# Altium schematic sheet GateDriver_LF.SchDoc(GateDriver_LF)
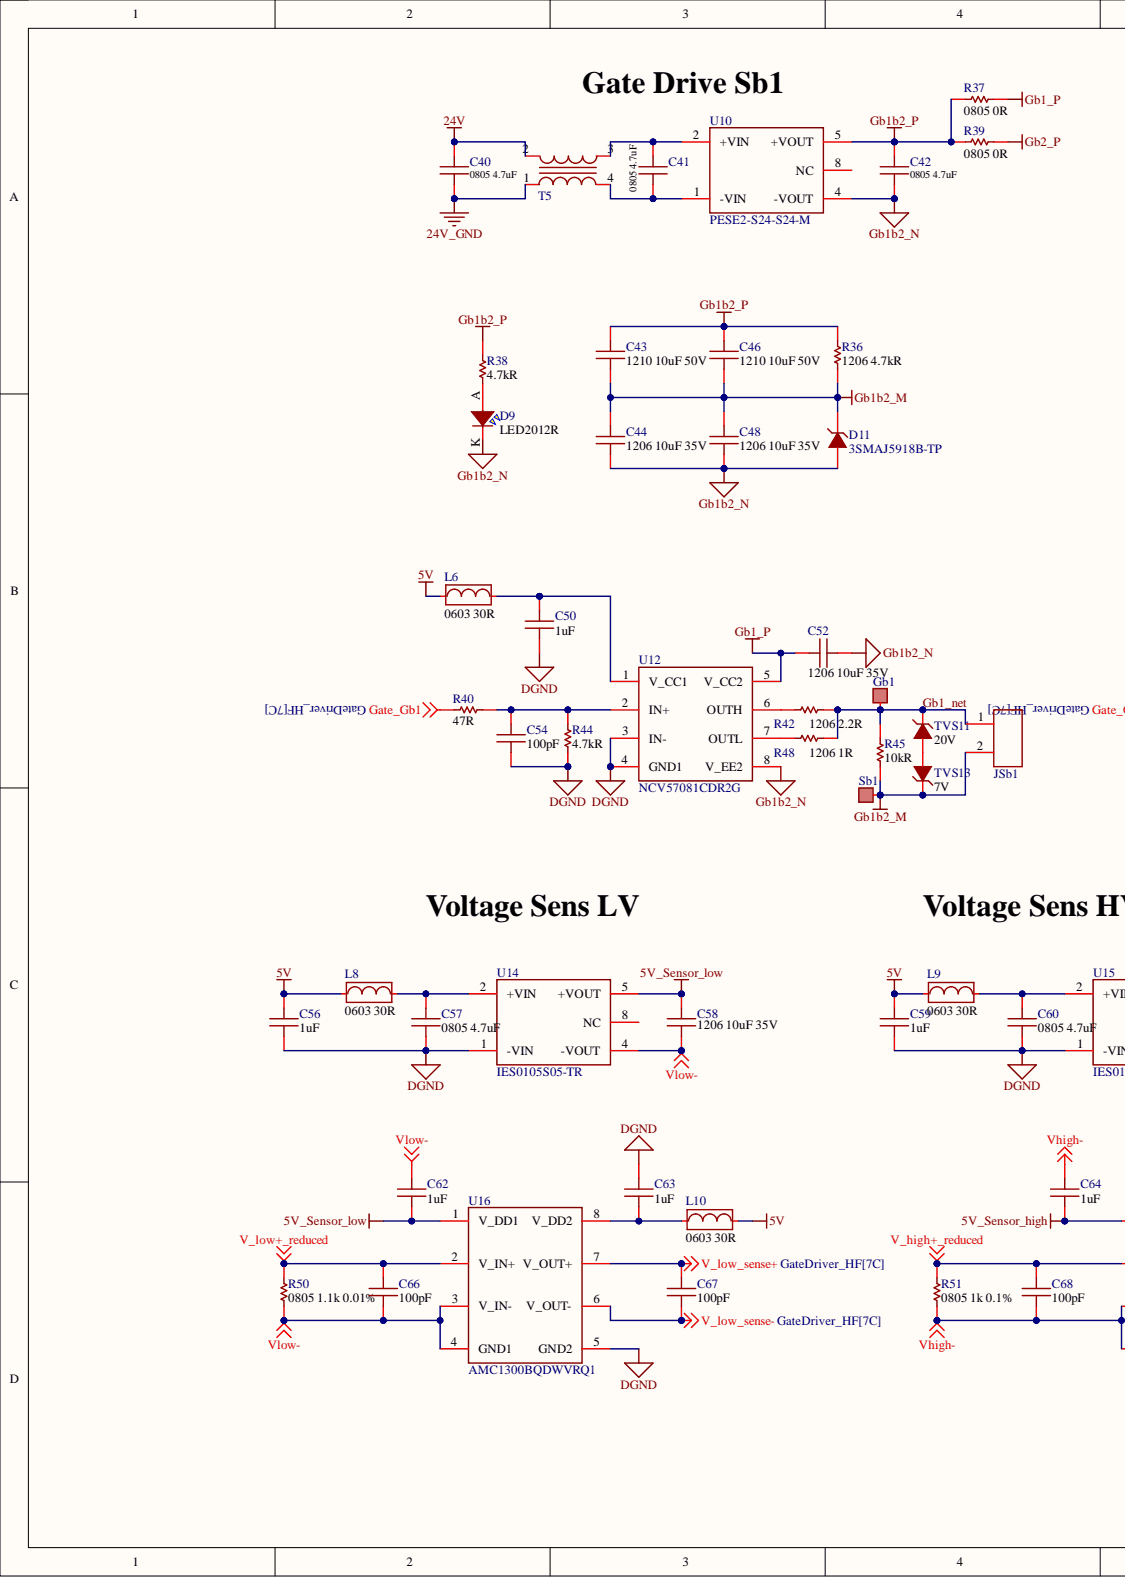
[diagram p0: GateDriver_LF.SchDoc, left]
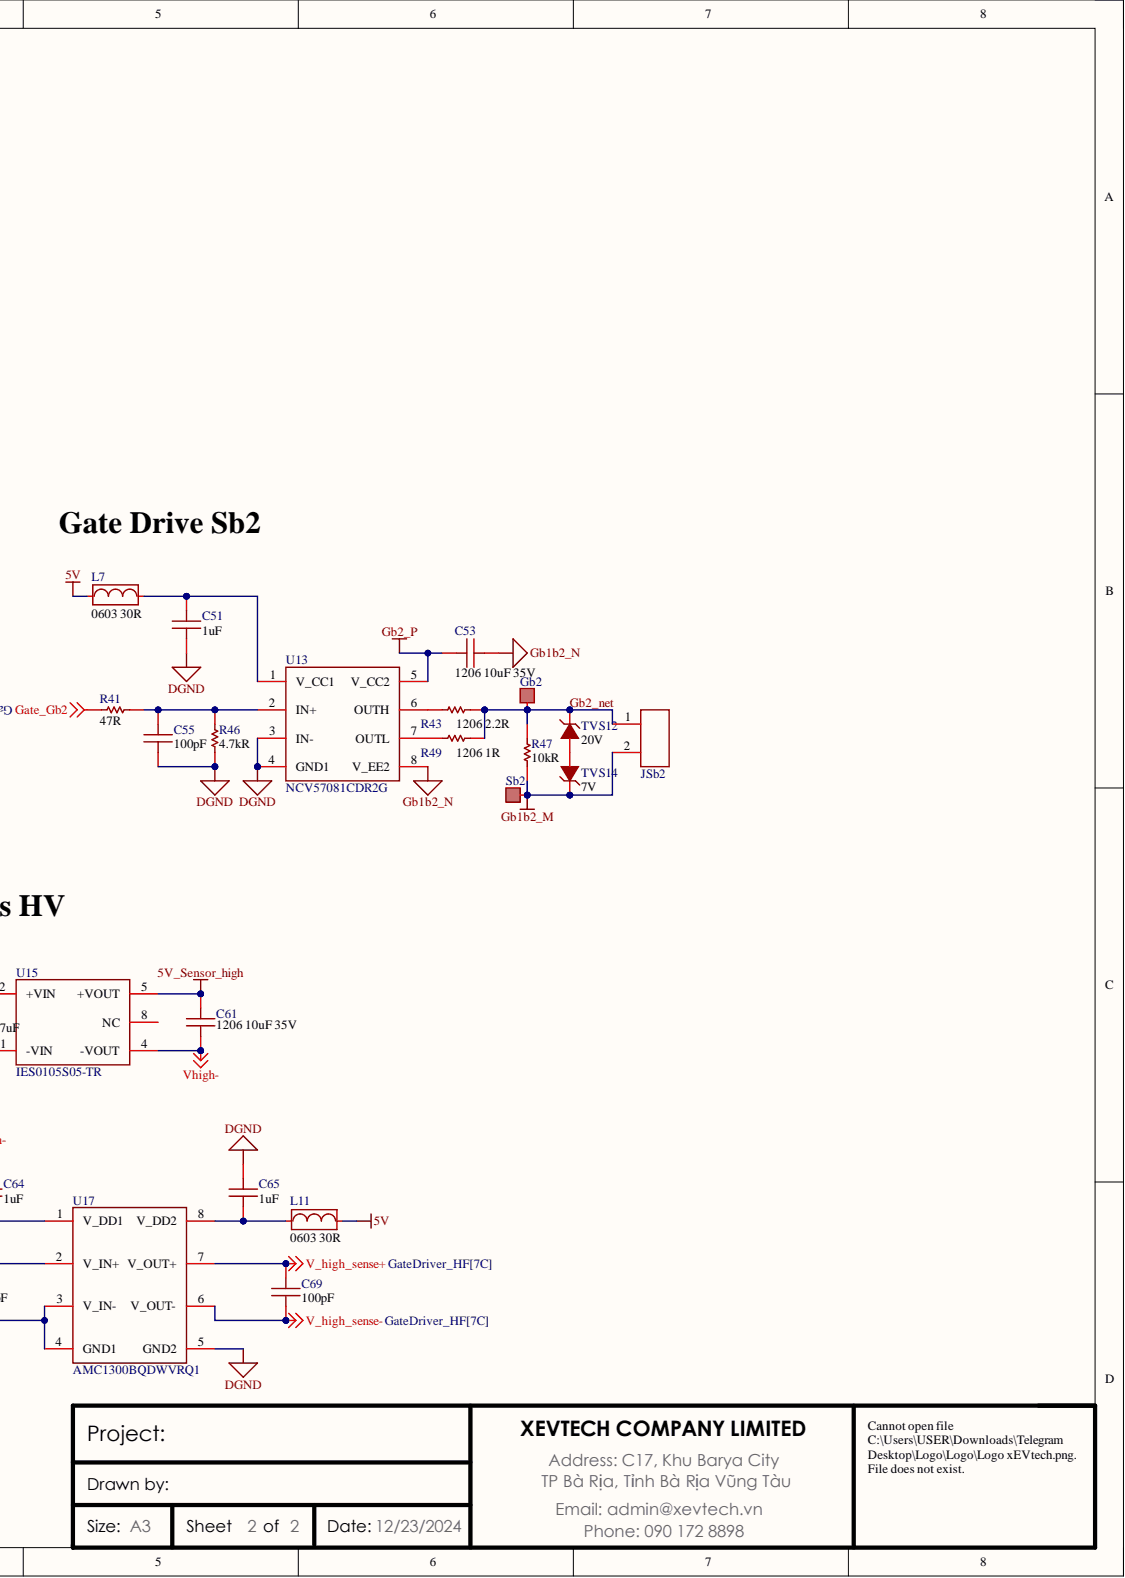
[diagram p1: GateDriver_LF.SchDoc, right]

## Parts
C40  value 0805 4.7uF  Case Code (Imperial): 0805  [CAP 0805]  (hidden comment: CGA4J1X7R1H475K125AC)
C41  value 0805 4.7uF  Capacitance: 4.7uF  Case Code (Imperial): 0805  [CAP 0805]  (hidden comment: CGA4J1X7R1H475K125AC)
C42  value 0805 4.7uF  Capacitance: 4.7uF  Case Code (Imperial): 0805  [CAP 0805]  (hidden comment: CGA4J1X7R1H475K125AC)
C43  value 1210 10uF 50V  [Cap 1210]  (hidden comment: KAM32LR71H106KU)
C44  value 1206 10uF 35V  Capacitance: 10uF  Case Code (Imperial): 1206  [Cap 1206]  (hidden comment: CGA5L1X7R1V106M160AC)
C46  value 1210 10uF 50V  [Cap 1210]  (hidden comment: KAM32LR71H106KU)
C48  value 1206 10uF 35V  Capacitance: 10uF  Case Code (Imperial): 1206  Voltage Rating: 35V  [Cap 1206]  (hidden comment: CGA5L1X7R1V106M160AC)
C50  value 1uF  Capacitance: 1uF  [CAP 0603]  (hidden comment: GCM188R71C105KA64J)
C51  value 1uF  Capacitance: 1uF  [CAP 0603]  (hidden comment: GCM188R71C105KA64J)
C52  value 1206 10uF 35V  Capacitance: 10uF  Case Code (Imperial): 1206  [Cap 1206]  (hidden comment: CGA5L1X7R1V106M160AC)
C53  value 1206 10uF 35V  Capacitance: 10uF  Case Code (Imperial): 1206  [Cap 1206]  (hidden comment: CGA5L1X7R1V106M160AC)
C54  Capacitance: 100pF  [CAP 0603]  (hidden comment: C0603C101J4GAC7867)
C55  Capacitance: 100pF  [CAP 0603]  (hidden comment: C0603C101J4GAC7867)
C56  value 1uF  Capacitance: 1uF  [CAP 0603]  (hidden comment: GCM188R71C105KA64J)
C57  value 0805 4.7uF  Case Code (Imperial): 0805  [CAP 0805]  (hidden comment: CGA4J1X7R1H475K125AC)
C58  value 1206 10uF 35V  Capacitance: 10uF  Case Code (Imperial): 1206  [Cap 1206]  (hidden comment: CGA5L1X7R1V106M160AC)
C59  value 1uF  Capacitance: 1uF  [CAP 0603]  (hidden comment: GCM188R71C105KA64J)
C60  value 0805 4.7uF  Case Code (Imperial): 0805  [CAP 0805]  (hidden comment: CGA4J1X7R1H475K125AC)
C61  value 1206 10uF 35V  Capacitance: 10uF  Case Code (Imperial): 1206  [Cap 1206]  (hidden comment: CGA5L1X7R1V106M160AC)
C62  value 1uF  Capacitance: 1uF  [CAP 0603]  (hidden comment: GCM188R71C105KA64J)
C63  value 1uF  Capacitance: 1uF  [CAP 0603]  (hidden comment: GCM188R71C105KA64J)
C64  value 1uF  Capacitance: 1uF  [CAP 0603]  (hidden comment: GCM188R71C105KA64J)
C65  value 1uF  Capacitance: 1uF  [CAP 0603]  (hidden comment: GCM188R71C105KA64J)
C66  Capacitance: 100pF  [CAP 0603]  (hidden comment: C0603C101J4GAC7867)
C67  Capacitance: 100pF  [CAP 0603]  (hidden comment: C0603C101J4GAC7867)
C68  Capacitance: 100pF  [CAP 0603]  (hidden comment: C0603C101J4GAC7867)
C69  Capacitance: 100pF  [CAP 0603]  (hidden comment: C0603C101J4GAC7867)
D9  value LED2012R  [LED-2012R]  (hidden comment: LED_Green)
D11  3SMAJ5918B-TP  [SMA (DO-214AC)]
Gb1  (no value)  [TP]
Gb2  (no value)  [TP]
JSb1  SLM-102-01-G-S  [Header fe 2p 1.27]  (value not drawn)
JSb2  SLM-102-01-G-S  [Header fe 2p 1.27]  (value not drawn)
L6  Case Code (Imperial): 0603  Parameter 1: 0603 30R  [Bead 1608 0603]  (hidden comment: BLM18KG300JH1D)
L7  Case Code (Imperial): 0603  Parameter 1: 0603 30R  [Bead 1608 0603]  (hidden comment: BLM18KG300JH1D)
L8  Case Code (Imperial): 0603  Parameter 1: 0603 30R  [Bead 1608 0603]  (hidden comment: BLM18KG300JH1D)
L9  BLM18KG300JH1D  [Bead 1608 0603]  (value not drawn)
L10  Case Code (Imperial): 0603  Parameter 1: 0603 30R  [Bead 1608 0603]  (hidden comment: BLM18KG300JH1D)
L11  Case Code (Imperial): 0603  Parameter 1: 0603 30R  [Bead 1608 0603]  (hidden comment: BLM18KG300JH1D)
R36  value 1206 4.7kR  [Res 1206]  (hidden comment: AC1206FR-074K7L)
R37  value 0805 0R  Case Code (Imperial): 0805  Resistance: 0R  [RES 0805]  (hidden comment: AC0805FR-070RL)
R38  value 4.7kR  [RES 0603]  (hidden comment: AC0603JR-074K7L)
R39  value 0805 0R  Case Code (Imperial): 0805  Resistance: 0R  [RES 0805]  (hidden comment: AC0805FR-070RL)
R40  value 47R  [RES 0603]  (hidden comment: AC0603FR-0747RL)
R41  value 47R  [RES 0603]  (hidden comment: AC0603FR-0747RL)
R42  value 1206 2.2R  [Res 1206]  (hidden comment: CRCW12062R20JNEAHP)
R43  value 1206 2.2R  [Res 1206]  (hidden comment: CRCW12062R20JNEAHP)
R44  value 4.7kR  [RES 0603]  (hidden comment: AC0603JR-074K7L)
R45  value 10kR  Resistance: 10kR  [RES 0603]  (hidden comment: CRCW060310K0FKEA)
R46  value 4.7kR  [RES 0603]  (hidden comment: AC0603JR-074K7L)
R47  value 10kR  Resistance: 10kR  [RES 0603]  (hidden comment: CRCW060310K0FKEA)
R48  value 1206 1R  [Res 1206]  (hidden comment: CRCW12061R00JNEAHP)
R49  value 1206 1R  [Res 1206]  (hidden comment: CRCW12061R00JNEAHP)
R50  value 0805 1.1k 0.01%  [RES 0805]  (hidden comment: RT0805BRD071K1L)
R51  value 0805 1k 0.1%  [RES 0805]  (hidden comment: RT0805BRD071KL)
Sb1  (no value)  [TP]
Sb2  (no value)  [TP]
T5  B82787C0513H002  [ACT45b-510-2p-t003]  (value not drawn)
TVS11  Parameter 1: 20V  [SOD-123FL]  (hidden comment: SMF20A)
TVS12  Parameter 1: 20V  [SOD-123FL]  (hidden comment: SMF20A)
TVS13  Parameter 1: 7V  [SOD-123FL]  (hidden comment: SMF7.0A)
TVS14  Parameter 1: 7V  [SOD-123FL]  (hidden comment: SMF7.0A)
U10  PESE2-S24-S24-M  [IES0224S24]
U12  NCV57081CDR2G  [SOIC8]
U13  NCV57081CDR2G  [SOIC8]
U14  IES0105S05-TR  [supply_voltage_sens]
U15  IES0105S05-TR  [supply_voltage_sens]
U16  AMC1300BQDWVRQ1  [Voltage_sens]
U17  AMC1300BQDWVRQ1  [Voltage_sens]

## Nets
5V: C56.1 C59.1 L6.1 L7.1 L8.1 L9.1 L10.2 L11.2
5V_Sensor_high: C61.1 C64.2 U15.5(+VOUT) U17.1(V_DD1)
5V_Sensor_low: C58.1 C62.2 U14.5(+VOUT) U16.1(V_DD1)
24V: C40.1 T5.2
24V_GND: C40.2 T5.1
DGND: C50.2 C51.2 C54.2 C55.2 C56.2 C57.2 C59.2 C60.2 C63.1 C65.1 R44.1 R46.1 U12.3(IN-) U12.4(GND1) U13.3(IN-) U13.4(GND1) U14.1(-VIN) U15.1(-VIN) U16.5(GND2) U17.5(GND2)
Gate_Gb1: R40.1
Gate_Gb2: R41.1
Gb1_P: C52.1 R37.2 U12.5(V_CC2)
Gb1b2_M: C43.2 C44.1 C46.2 C48.1 D11.K JSb1.2 JSb2.2 R36.1 R45.2 R47.2 Sb1.1 Sb2.1 TVS13.K TVS14.K
Gb1b2_N: C42.2 C44.2 C48.2 C52.2 C53.2 D9.K D11.A U10.4(-VOUT) U12.8(V_EE2) U13.8(V_EE2)
Gb1b2_P: C42.1 C43.1 C46.1 R36.2 R37.1 R38.2 R39.1 U10.5(+VOUT)
Gb2_P: C53.1 R39.2 U13.5(V_CC2)
V_high+_reduced: C68.2 R51.2 U17.2(V_IN+)
V_high_sense+: C69.2 U17.7(V_OUT+)
V_high_sense-: C69.1 U17.6(V_OUT-)
V_low+_reduced: C66.2 R50.2 U16.2(V_IN+)
V_low_sense+: C67.2 U16.7(V_OUT+)
V_low_sense-: C67.1 U16.6(V_OUT-)
Vhigh-: C61.2 C64.1 C68.1 R51.1 U15.4(-VOUT) U17.3(V_IN-) U17.4(GND1)
Vlow-: C58.2 C62.1 C66.1 R50.1 U14.4(-VOUT) U16.3(V_IN-) U16.4(GND1)
Gb1_net: Gb1.1 JSb1.1 R42.2 R45.1 R48.2 TVS11.K
Gb2_net: Gb2.1 JSb2.1 R43.2 R47.1 R49.2 TVS12.K
NetC41_1: C41.1 T5.3 U10.2(+VIN)
NetC41_2: C41.2 T5.4 U10.1(-VIN)
NetC50_1: C50.1 L6.2 U12.1(V_CC1)
NetC51_1: C51.1 L7.2 U13.1(V_CC1)
NetC54_1: C54.1 R40.2 R44.2 U12.2(IN+)
NetC55_1: C55.1 R41.2 R46.2 U13.2(IN+)
NetC57_1: C57.1 L8.2 U14.2(+VIN)
NetC60_1: C60.1 L9.2 U15.2(+VIN)
NetC63_2: C63.2 L10.1 U16.8(V_DD2)
NetC65_2: C65.2 L11.1 U17.8(V_DD2)
NetD9_A: D9.A R38.1
NetR42_1: R42.1 U12.6(OUTH)
NetR43_1: R43.1 U13.6(OUTH)
NetR48_1: R48.1 U12.7(OUTL)
NetR49_1: R49.1 U13.7(OUTL)
NetTVS11_A: TVS11.A TVS13.A
NetTVS12_A: TVS12.A TVS14.A

Not connected: U10 8(NC); U14 8(NC); U15 8(NC)

## Library data of the parts
C40 C57 C60 = Cap 0805 4.7uF: 4.7 µF ±10% 50V Ceramic Capacitor X7R 0805 (2012 Metric); Manufacturer 1: TDK; Capacitance: 4.7uF; Category 1: Ceramic Capacitors; Description 1: Multilayer Ceramic Capacitor, 4.7 uF, 50 V, ± 10%, X7R, 0805 [2012 Metric]; Material: 4241ConductorMaterial; Case Code (Metric): 2012; Case/Package: 0805; Component Kind: Standard; Height: 1.45mm; Length: 2mm; Manufacturer Part Number 1: CGA4J1X7R1H475K125AC; Max Operating Temperature: 125°C; Min Operating Temperature: -55°C; Mount: Surface Mount; Packaging: Cut Tape; Ratings: AEC-Q200; RoHS 1: Yes; Series: CGA; Supplier 1: Digi-Key; Tolerance: 10%; Value: 0805 4.7uF; Voltage Rating: 50V; Width: 1.25mm; Library Name: xEV_SmartOBC.SchLib
  C40: ComponentLink1Description: https://product.tdk.com/info/en/catalog/datasheets/mlcc_automotive_general_en.pdf?src-supplier=Digi-Key; ComponentLink1URL: https://product.tdk.com/info/en/catalog/datasheets/mlcc_automotive_general_en.pdf?src-supplier=Digi-Key; ComponentLink2Description: https://product.tdk.com/info/en/catalog/datasheets/mlcc_automotive_general_en.pdf?ref_disty=digikey&src-supplier=Digi-Key; ComponentLink2URL: https://product.tdk.com/info/en/catalog/datasheets/mlcc_automotive_general_en.pdf?ref_disty=digikey&src-supplier=Digi-Key; ComponentLink3Description: https://datasheet.octopart.com/CGA4J1X7R1H475K125AC-TDK-datasheet-138890726.pdf?src-supplier=Future+Electronics; ComponentLink3URL: https://datasheet.octopart.com/CGA4J1X7R1H475K125AC-TDK-datasheet-138890726.pdf?src-supplier=Future+Electronics; ComponentLink4Description: https://datasheet.octopart.com/CGA4J1X7R1H475K125AC-TDK-datasheet-165583812.pdf?src-supplier=TME; ComponentLink4URL: https://datasheet.octopart.com/CGA4J1X7R1H475K125AC-TDK-datasheet-165583812.pdf?src-supplier=TME; Pricing 1: 1=0,54, 10=0,361, 50=0,2874, 100=0,2373, 500=0,1854, 1000=0,15759 (USD); Stock 1: 126167; Supplier Part Number 1: 445-181404-6-ND
  C57 C60: ComponentLink1Description: https://datasheet.octopart.com/GRM21BR61H106KE43L-Murata-datasheet-155345082.pdf?src-supplier=Element14; ComponentLink1URL: https://datasheet.octopart.com/GRM21BR61H106KE43L-Murata-datasheet-155345082.pdf?src-supplier=Element14; ComponentLink2Description: https://search.murata.co.jp/Ceramy/image/img/A01X/G101/ENG/GRM21BR61H106KE43-01A.pdf?src-supplier=Digi-Key; ComponentLink2URL: https://search.murata.co.jp/Ceramy/image/img/A01X/G101/ENG/GRM21BR61H106KE43-01A.pdf?src-supplier=Digi-Key; ComponentLink3Description: https://datasheet.octopart.com/GRM21BR61H106KE43L-Murata-datasheet-165579666.pdf?src-supplier=TME; ComponentLink3URL: https://datasheet.octopart.com/GRM21BR61H106KE43L-Murata-datasheet-165579666.pdf?src-supplier=TME; ComponentLink4Description: https://product.tdk.com/info/en/catalog/datasheets/mlcc_automotive_general_en.pdf?src-supplier=Digi-Key; ComponentLink4URL: https://product.tdk.com/info/en/catalog/datasheets/mlcc_automotive_general_en.pdf?src-supplier=Digi-Key; ComponentLink5Description: https://product.tdk.com/info/en/catalog/datasheets/mlcc_automotive_general_en.pdf?ref_disty=digikey&src-supplier=Digi-Key; ComponentLink5URL: https://product.tdk.com/info/en/catalog/datasheets/mlcc_automotive_general_en.pdf?ref_disty=digikey&src-supplier=Digi-Key; ComponentLink6Description: https://datasheet.octopart.com/CGA4J1X7R1H475K125AC-TDK-datasheet-138890726.pdf?src-supplier=Future+Electronics; ComponentLink6URL: https://datasheet.octopart.com/CGA4J1X7R1H475K125AC-TDK-datasheet-138890726.pdf?src-supplier=Future+Electronics; ComponentLink7Description: https://datasheet.octopart.com/CGA4J1X7R1H475K125AC-TDK-datasheet-165583812.pdf?src-supplier=TME; ComponentLink7URL: https://datasheet.octopart.com/CGA4J1X7R1H475K125AC-TDK-datasheet-165583812.pdf?src-supplier=TME; Pricing 1: 1=0,53, 10=0,373, 50=0,2818, 100=0,2454, 500=0,1818, 1000=0,15453 (USD); Stock 1: 46839; Supplier Part Number 1: 445-181404-1-ND
C41 C42 = Cap 0805 4.7uF: 4.7 µF ±10% 50V Ceramic Capacitor X7R 0805 (2012 Metric); Manufacturer 1: TDK; Category 1: Ceramic Capacitors; Description 1: Multilayer Ceramic Capacitor, 4.7 uF, 50 V, ± 10%, X7R, 0805 [2012 Metric]; Material: 4241ConductorMaterial; Case Code (Metric): 2012; Case/Package: 0805; Component Kind: Standard; ComponentLink1Description: https://product.tdk.com/info/en/catalog/datasheets/mlcc_automotive_general_en.pdf?src-supplier=Digi-Key; ComponentLink1URL: https://product.tdk.com/info/en/catalog/datasheets/mlcc_automotive_general_en.pdf?src-supplier=Digi-Key; ComponentLink2Description: https://product.tdk.com/info/en/catalog/datasheets/mlcc_automotive_general_en.pdf?ref_disty=digikey&src-supplier=Digi-Key; ComponentLink2URL: https://product.tdk.com/info/en/catalog/datasheets/mlcc_automotive_general_en.pdf?ref_disty=digikey&src-supplier=Digi-Key; ComponentLink3Description: https://datasheet.octopart.com/CGA4J1X7R1H475K125AC-TDK-datasheet-138890726.pdf?src-supplier=Future+Electronics; ComponentLink3URL: https://datasheet.octopart.com/CGA4J1X7R1H475K125AC-TDK-datasheet-138890726.pdf?src-supplier=Future+Electronics; ComponentLink4Description: https://datasheet.octopart.com/CGA4J1X7R1H475K125AC-TDK-datasheet-165583812.pdf?src-supplier=TME; ComponentLink4URL: https://datasheet.octopart.com/CGA4J1X7R1H475K125AC-TDK-datasheet-165583812.pdf?src-supplier=TME; Height: 1.45mm; Length: 2mm; Manufacturer Part Number 1: CGA4J1X7R1H475K125AC; Max Operating Temperature: 125°C; Min Operating Temperature: -55°C; Mount: Surface Mount; Packaging: Cut Tape; Pricing 1: 1=0,54, 10=0,361, 50=0,2874, 100=0,2373, 500=0,1854, 1000=0,15759 (USD); Ratings: AEC-Q200; RoHS 1: Yes; Series: CGA; Stock 1: 126167; Supplier 1: Digi-Key; Supplier Part Number 1: 445-181404-6-ND; Tolerance: 10%; Voltage Rating: 50V; Width: 1.25mm; Library Name: xEV_SmartOBC.SchLib
C43 = Cap 1210 10uF: 10 µF ±10% 50V Ceramic Capacitor X7R 1210 (3225 Metric); Manufacturer 1: Kyocera AVX; Category 1: Uncategorized; Description 1: Cap Cer 10UF 50V X7R 1210; Value: 1210 10uF 50V; Component Kind: Standard; Manufacturer Part Number 1: KAM32LR71H106KU; Pricing 1: 1=0,8, 10=0,608, 50=0,5052, 100=0,435, 500=0,33678 (USD); RoHS 1: No; Stock 1: 40044; Supplier 1: Digi-Key; Supplier Part Number 1: 478-KAM32LR71H106KUCT-ND; Library Name: xEV_SmartOBC.SchLib
C44 C52 C53 = Cap 1206 10uF: 10 µF ±20% 35V Ceramic Capacitor X7R 1206 (3216 Metric); Manufacturer 1: TDK; Category 1: Ceramic Capacitors; Description 1: Cap Ceramic 10uF 35V X7R 20% SMD 1206 125°C Blister Plastic T/R; Value: 1206 10uF 35V; Case Code (Metric): 3216; Case/Package: 1206; Component Kind: Standard; ComponentLink1Description: https://product.tdk.com/info/en/catalog/datasheets/mlcc_automotive_general_en.pdf?src-supplier=Digi-Key; ComponentLink1URL: https://product.tdk.com/info/en/catalog/datasheets/mlcc_automotive_general_en.pdf?src-supplier=Digi-Key; ComponentLink2Description: http://product.tdk.com/capacitor/mlcc/detailed_information.php?lang=en&ref=jp&part_no=CGA5L1X7R1V106M160AC&src-supplier=Mouser; ComponentLink2URL: http://product.tdk.com/capacitor/mlcc/detailed_information.php?lang=en&ref=jp&part_no=CGA5L1X7R1V106M160AC&src-supplier=Mouser; ComponentLink3Description: http://product.tdk.com/capacitor/mlcc/class/print_detail_pdf.php?lang=en&part_no=CGA5L1X7R1V106M160AC&src-supplier=Avnet; ComponentLink3URL: http://product.tdk.com/capacitor/mlcc/class/print_detail_pdf.php?lang=en&part_no=CGA5L1X7R1V106M160AC&src-supplier=Avnet; ComponentLink4Description: https://product.tdk.com/info/en/catalog/spec/mlccspec_automotive_general_midvoltage_en.pdf?src-supplier=Digi-Key; ComponentLink4URL: https://product.tdk.com/info/en/catalog/spec/mlccspec_automotive_general_midvoltage_en.pdf?src-supplier=Digi-Key; Dielectric: X7R; Height: 1.6mm; Length: 0.126inch; Manufacturer Part Number 1: CGA5L1X7R1V106M160AC; Max Operating Temperature: 125°C; Min Operating Temperature: -55°C; Mount: Surface Mount; Package Quantity: 2000; Packaging: Tape and Reel; Pricing 1: 1=0,72, 10=0,53, 50=0,4242, 100=0,3636, 500=0,28784, 1000=0,2424 (USD); Ratings: AEC-Q200; RoHS 1: Yes; RoHS Compliant: Yes; Series: CGA; Stock 1: 4126; Supplier 1: Digi-Key; Supplier Part Number 1: 445-175201-1-ND; Termination: SMD/SMT; Thickness: 0.071inch; Tolerance: 20%; Voltage Rating: 35V; Voltage Rating (DC): 35V; Weight: 0.000571oz; Width: 1.6mm; Library Name: xEV_SmartOBC.SchLib
C46 = Cap 1210 10uF: 10 µF ±10% 50V Ceramic Capacitor X7R 1210 (3225 Metric); Manufacturer 1: Kyocera AVX; Category 1: Uncategorized; Description 1: Cap Cer 10UF 50V X7R 1210; Component Kind: Standard; Manufacturer Part Number 1: KAM32LR71H106KU; Pricing 1: 1=0,8, 10=0,608, 50=0,5052, 100=0,435, 500=0,33678 (USD); RoHS 1: No; Stock 1: 40044; Supplier 1: Digi-Key; Supplier Part Number 1: 478-KAM32LR71H106KUCT-ND; Library Name: xEV_SmartOBC.SchLib
C48 C58 C61 = Cap 1206 10uF: 10 µF ±20% 35V Ceramic Capacitor X7R 1206 (3216 Metric); Manufacturer 1: TDK; Category 1: Ceramic Capacitors; Description 1: Cap Ceramic 10uF 35V X7R 20% SMD 1206 125°C Blister Plastic T/R; Case Code (Metric): 3216; Case/Package: 1206; Component Kind: Standard; ComponentLink1Description: https://product.tdk.com/info/en/catalog/datasheets/mlcc_automotive_general_en.pdf?src-supplier=Digi-Key; ComponentLink1URL: https://product.tdk.com/info/en/catalog/datasheets/mlcc_automotive_general_en.pdf?src-supplier=Digi-Key; ComponentLink2Description: http://product.tdk.com/capacitor/mlcc/detailed_information.php?lang=en&ref=jp&part_no=CGA5L1X7R1V106M160AC&src-supplier=Mouser; ComponentLink2URL: http://product.tdk.com/capacitor/mlcc/detailed_information.php?lang=en&ref=jp&part_no=CGA5L1X7R1V106M160AC&src-supplier=Mouser; ComponentLink3Description: http://product.tdk.com/capacitor/mlcc/class/print_detail_pdf.php?lang=en&part_no=CGA5L1X7R1V106M160AC&src-supplier=Avnet; ComponentLink3URL: http://product.tdk.com/capacitor/mlcc/class/print_detail_pdf.php?lang=en&part_no=CGA5L1X7R1V106M160AC&src-supplier=Avnet; ComponentLink4Description: https://product.tdk.com/info/en/catalog/spec/mlccspec_automotive_general_midvoltage_en.pdf?src-supplier=Digi-Key; ComponentLink4URL: https://product.tdk.com/info/en/catalog/spec/mlccspec_automotive_general_midvoltage_en.pdf?src-supplier=Digi-Key; Dielectric: X7R; Height: 1.6mm; Length: 0.126inch; Manufacturer Part Number 1: CGA5L1X7R1V106M160AC; Max Operating Temperature: 125°C; Min Operating Temperature: -55°C; Mount: Surface Mount; Package Quantity: 2000; Packaging: Tape and Reel; Pricing 1: 1=0,72, 10=0,53, 50=0,4242, 100=0,3636, 500=0,28784, 1000=0,2424 (USD); Ratings: AEC-Q200; RoHS 1: Yes; RoHS Compliant: Yes; Series: CGA; Stock 1: 4126; Supplier 1: Digi-Key; Supplier Part Number 1: 445-175201-1-ND; Termination: SMD/SMT; Thickness: 0.071inch; Tolerance: 20%; Voltage Rating (DC): 35V; Weight: 0.000571oz; Width: 1.6mm; Library Name: xEV_SmartOBC.SchLib
  C58 C61: Voltage Rating: 35V
C50 C51 C56 C59 C62-C65 = Cap 0603 1uF: CAP, CERM, 1 µF, 16 V, +/- 10%, X7R, 0603; Manufacturer 1: Murata; Category 1: Ceramic Capacitors; Description 1: Multilayer Ceramic Capacitor, 1 uF, 16 V, ± 10%, X7R, 0603 [1608 Metric]; Voltage Rating: 16V; Case Code (Imperial): 0603; Case Code (Metric): 1608; Case/Package: 0603; Component Kind: Standard; ComponentLink1Description: https://datasheet.ciiva.com/26947/getdatasheetpartid-789976-26947662.pdf?src-supplier=Verical; ComponentLink1URL: https://datasheet.ciiva.com/26947/getdatasheetpartid-789976-26947662.pdf?src-supplier=Verical; Depth: 0.8mm; Dielectric: X7R; Lead Free: Lead Free; Length: 1.6mm; Manufacturer Part Number 1: GCM188R71C105KA64J; Max Operating Temperature: 125°C; Min Operating Temperature: -55°C; Mount: Surface Mount; Packaging: Tape and Reel; Pricing 1: 1=0,18, 10=0,119, 50=0,075, 100=0,0601, 500=0,04338, 1000=0,03838, 2500=0,03671, 5000=0,03337 (USD); Radiation Hardening: No; Ratings: AEC-Q200; RoHS 1: Yes; RoHS Compliant: Yes; Series: GCM; Stock 1: 62628; Supplier 1: Digi-Key; Supplier Part Number 1: 490-12657-6-ND; Thickness: 0.035inch; Tolerance: 10%; Voltage Rating (DC): 16V; Width: 0.031inch; Library Name: xEV_SmartOBC.SchLib
C54 C55 C66-C69 = CAP 0603 100pF: CAP, CERM, 100 pF, 16 V, +/- 5%, C0G,NP0, 0603; Manufacturer 1: KEMET; Category 1: Ceramic Capacitors; Description 1: Cap Ceramic 100pF 16V C0G 5% SMD 0603 125C Paper T/R; Dielectric Material: Ceramic; Material: 4241ConductorMaterial; Temperature Coefficient: 30ppm/°C; Voltage Rating: 16V; Case/Package: 0603; Component Kind: Standard; ComponentLink1Description: https://api.kemet.com/component-edge/download/datasheet/C0603C101J4GACTU.pdf?src-supplier=Digi-Key; ComponentLink1URL: https://api.kemet.com/component-edge/download/datasheet/C0603C101J4GACTU.pdf?src-supplier=Digi-Key; ComponentLink2Description: https://search.kemet.com/component-edge/download/datasheet/C0603C101J4GACTU.pdf?src-supplier=Digi-Key; ComponentLink2URL: https://search.kemet.com/component-edge/download/datasheet/C0603C101J4GACTU.pdf?src-supplier=Digi-Key; ComponentLink3Description: http://capacitoredge.kemet.com/capedge2/DataSheet?pn=C0603C101J4GAC7867&src-supplier=Avnet; ComponentLink3URL: http://capacitoredge.kemet.com/capedge2/DataSheet?pn=C0603C101J4GAC7867&src-supplier=Avnet; ComponentLink4Description: http://capacitoredge.kemet.com/capedge2/DataSheet?pn=C0603C101J4GAC7867; ComponentLink4URL: http://capacitoredge.kemet.com/capedge2/DataSheet?pn=C0603C101J4GAC7867; Construction: Flat; Depth: 0.8mm; Dielectric: C0G; Height: 0.8mm; Lead Free: Lead Free; Length: 1.6mm; Manufacturer Part Number 1: C0603C101J4GAC7867; Max Operating Temperature: 125°C; Min Operating Temperature: -55°C; Mount: Surface Mount; Packaging: Tape and Reel; Parameter 1: 100pF; Pricing 1: 1=0,23, 10=0,151, 50=0,1062, 100=0,0858, 500=0,06376, 1000=0,05578 (USD); Radiation Hardening: No; RoHS 1: Yes; RoHS Compliant: Yes; Series: SMD Comm C0G; Stock 1: 12614; Supplier 1: Digi-Key; Supplier Part Number 1: 399-14476-6-ND; Tolerance: 5%; Voltage Rating (DC): 16V; Library Name: xEV_SmartOBC.SchLib
D9 = LED_Green: Led; Manufacturer 1: Wurth Electronics; Manufacturer Part Number 1: 150080VS75000; Category 1: LEDs; Color: Green; Description 1: WL-SMCW SMT Mono-Color Chip LED Waterclear; Dominant Wavelength: 570nm; Forward Current: 30mA; Forward Voltage: 2V; Lens Color: Colorless; Lens Size: 1.25mm; Lens Style: Clear,Flat,Rectangular; Lens Transparency: Clear; Luminous Intensity: 40mcd; Max Reverse Voltage (DC): 5V; Power Dissipation: 72mW; Test Current: 20mA; Viewing Angle: 140°; Case Code (Imperial): 0805; Case Code (Metric): 2012; Case/Package: 0805; Component Kind: Standard; ComponentLink1Description: https://datasheet.ciiva.com/22656/150080vs75000-22656966.pdf?src-supplier=Element14; ComponentLink1URL: https://datasheet.ciiva.com/22656/150080vs75000-22656966.pdf?src-supplier=Element14; ComponentLink2Description: https://datasheet.ciiva.com/29279/759437-da-01-en-chipled-0805-w-kl-h-gruen-150080vs7500-29279047.pdf?src-supplier=Conrad; ComponentLink2URL: https://datasheet.ciiva.com/29279/759437-da-01-en-chipled-0805-w-kl-h-gruen-150080vs7500-29279047.pdf?src-supplier=Conrad; ComponentLink3Description: https://datasheet.ciiva.com/29563/150080vs75000-29563018.pdf?src-supplier=Digi-Key; ComponentLink3URL: https://datasheet.ciiva.com/29563/150080vs75000-29563018.pdf?src-supplier=Digi-Key; Height: 0.8mm; Length: 2mm; Max Operating Temperature: 85°C; Min Operating Temperature: -40°C; Mount: Surface Mount; Package Quantity: 1; Packaging: Cut Tape; Pricing 1: 1=0,19, 50=0,176, 100=0,151, 500=0,131 (USD); Reach SVHC Compliant: Unknown; Reverse Voltage: 5V; RoHS 1: Yes; RoHS Compliant: Yes; Series: WL-SMCW; Stock 1: 33464; Supplier 1: Digi-Key; Supplier Part Number 1: 732-4986-6-ND; Wavelength: 570nm; Width: 1.25mm; Library Name: xEV_SmartOBC.SchLib
D11 = Zenner_5.1V: Zener Diode 5.1 V 3 W ±5% Surface Mount DO-214AC (SMA); Component Kind: Standard; Supplier 1: Digi-Key; Supplier Part Number 1: 3SMAJ5918B-TPMSCT-ND; Library Name: xEV_SmartOBC.SchLib
Gb1 Gb2 Sb1 Sb2 = TP: Test Point; Value: TP; Component Kind: Standard; Library Name: xEV_SmartOBC.SchLib
JSb1 JSb2 = Header female 2p 1.27: Headers & Wire Housings Through-Hole Micro Socket, 0.050 x 0.100 Pitch; Manufacturer: Samtec; Category: Connectors; Component Kind: Standard; ComponentLink1Description: http://www.samtec.com/documents/webfiles/pdf/sms_TH.pdf?src-supplier=Avnet; ComponentLink1URL: http://www.samtec.com/documents/webfiles/pdf/sms_TH.pdf?src-supplier=Avnet; ComponentLink2Description: http://suddendocs.samtec.com/catalog_english/slm_th.pdf?src-supplier=Digikey; ComponentLink2URL: http://suddendocs.samtec.com/catalog_english/slm_th.pdf?src-supplier=Digikey; ComponentLink3Description: https://datasheet.octopart.com/SLM-102-01-G-S-Samtec-datasheet-151470493.pdf?src-supplier=Sager+Electronics; ComponentLink3URL: https://datasheet.octopart.com/SLM-102-01-G-S-Samtec-datasheet-151470493.pdf?src-supplier=Sager+Electronics; ComponentLink4Description: https://datasheet.octopart.com/SLM-102-01-G-S-Samtec-datasheet-97281254.pdf?src-supplier=Samtec; ComponentLink4URL: https://datasheet.octopart.com/SLM-102-01-G-S-Samtec-datasheet-97281254.pdf?src-supplier=Samtec; ComponentLink5Description: https://datasheet.octopart.com/SLM-102-01-G-S-Samtec-datasheet-136395472.pdf?src-supplier=Toby+Electronics; ComponentLink5URL: https://datasheet.octopart.com/SLM-102-01-G-S-Samtec-datasheet-136395472.pdf?src-supplier=Toby+Electronics; Manufacturer Part Number: SLM-102-01-G-S; Pricing 1: 1=0.54, 50=0.42, 100=0.34, 500=0.29, 1000=0.2625 (USD); RoHS: Yes; Stock 1: 1940; Supplier 1: Digikey; Supplier Part Number 1: SAM15258-ND; Library Name: xEV_SmartOBC.SchLib
L6-L8 L10 L11 = Bead-1608-0603: FERRITE BEAD 30 OHM 0603 1LN; Manufacturer 1: Murata; Category 1: Ferrite Beads and Chips; Description 1: Ferrite Beads Multi-Layer Power 30Ohm 25% 100MHz 3.3A 0.01Ohm DCR 0603 Automotive T/R; Max Current Rating: 3.3A; Number of Lines: 1; Case Code (Metric): 1608; Case/Package: 0603; Component Kind: Standard; ComponentLink1Description: https://www.murata.com/en-us/products/productdata/8796733866014/S0594E.pdf?src-supplier=Digi-Key; ComponentLink1URL: https://www.murata.com/en-us/products/productdata/8796733866014/S0594E.pdf?src-supplier=Digi-Key; ComponentLink2Description: http://www.murata.com/en-us/products/productdata/8798137286686/QNFA9129.pdf?src-supplier=Digi-Key; ComponentLink2URL: http://www.murata.com/en-us/products/productdata/8798137286686/QNFA9129.pdf?src-supplier=Digi-Key; Manufacturer Part Number 1: BLM18KG300JH1D; Max Operating Temperature: 150°C; Min Operating Temperature: -55°C; Mount: Surface Mount; Pricing 1: 1=0,11, 10=0,086, 25=0,0772, 50=0,0566, 100=0,0489, 250=0,03864, 500=0,03262, 1000=0,02747 (USD); Ratings: AEC-Q200; RoHS 1: Yes; RoHS Compliant: Yes; Series: EMIFIL®, BLM18K; Stock 1: 16948; Supplier 1: Digi-Key; Supplier Part Number 1: 490-14027-1-ND; Library Name: xEV_SmartOBC.SchLib
L9 = Bead-1608-0603: FERRITE BEAD 30 OHM 0603 1LN; Manufacturer 1: Murata; Category 1: Ferrite Beads and Chips; Description 1: Ferrite Beads Multi-Layer Power 30Ohm 25% 100MHz 3.3A 0.01Ohm DCR 0603 Automotive T/R; Max Current Rating: 3.3A; Number of Lines: 1; Parameter 1: 0603 30R; Case Code (Imperial): 0603; Case Code (Metric): 1608; Case/Package: 0603; Component Kind: Standard; ComponentLink1Description: https://www.murata.com/en-us/products/productdata/8796733866014/S0594E.pdf?src-supplier=Digi-Key; ComponentLink1URL: https://www.murata.com/en-us/products/productdata/8796733866014/S0594E.pdf?src-supplier=Digi-Key; ComponentLink2Description: http://www.murata.com/en-us/products/productdata/8798137286686/QNFA9129.pdf?src-supplier=Digi-Key; ComponentLink2URL: http://www.murata.com/en-us/products/productdata/8798137286686/QNFA9129.pdf?src-supplier=Digi-Key; Manufacturer Part Number 1: BLM18KG300JH1D; Max Operating Temperature: 150°C; Min Operating Temperature: -55°C; Mount: Surface Mount; Pricing 1: 1=0,11, 10=0,086, 25=0,0772, 50=0,0566, 100=0,0489, 250=0,03864, 500=0,03262, 1000=0,02747 (USD); Ratings: AEC-Q200; RoHS 1: Yes; RoHS Compliant: Yes; Series: EMIFIL®, BLM18K; Stock 1: 16948; Supplier 1: Digi-Key; Supplier Part Number 1: 490-14027-1-ND; Library Name: xEV_SmartOBC.SchLib
R36 = RES_1206_4.7k: 4.7 kOhms ±1% 0.25W, 1/4W Chip Resistor 1206 (3216 Metric) Automotive AEC-Q200, Moisture Resistant Thick Film; Manufacturer 1: Yageo; Category 1: Chip SMD Resistors; Description 1: Res Thick Film 1206 4.7K Ohm 1% 1/4W ±100ppm/°C Molded SMD SMD Paper T/R; Component Kind: Standard; ComponentLink1Description: https://datasheet.ciiva.com/12576/pyu-ac-51-rohs-l-2-12576679.pdf?src-supplier=Mouser; ComponentLink1URL: https://datasheet.ciiva.com/12576/pyu-ac-51-rohs-l-2-12576679.pdf?src-supplier=Mouser; Manufacturer Part Number 1: AC1206FR-074K7L; Pricing 1: 1=0,1, 10=0,044, 50=0,0286, 100=0,0242, 500=0,01704, 1000=0,01484 (USD); RoHS 1: Yes; Stock 1: 52377; Supplier 1: Digi-Key; Supplier Part Number 1: YAG3884CT-ND; Library Name: xEV_SmartOBC.SchLib
R37 R39 = RES_0805_0: RES 0 OHM JUMPER 1/8W 0805; Manufacturer 1: Yageo; Category 1: Chip SMD Resistors; Description 1: Res Thick Film 0805 0 Ohm 1% 1/8W Molded SMD Paper T/R; Power Rating: 125mW; Temperature Coefficient: 200ppm/°C; Tolerance: 1%; Voltage Rating: 150V; Case Code (Metric): 2012; Case/Package: 0805; Component Kind: Standard; ComponentLink1Description: https://datasheet.octopart.com/AC0805JR-070RL-Yageo-datasheet-166720450.pdf?src-supplier=Future+Electronics; ComponentLink1URL: https://datasheet.octopart.com/AC0805JR-070RL-Yageo-datasheet-166720450.pdf?src-supplier=Future+Electronics; ComponentLink2Description: http://www.yageo.com/documents/recent/PYu-AC_51_RoHS_L_2.pdf?src-supplier=Mouser; ComponentLink2URL: http://www.yageo.com/documents/recent/PYu-AC_51_RoHS_L_2.pdf?src-supplier=Mouser; ComponentLink3Description: https://datasheet.ciiva.com/12656/pyu-ac-51-rohs-l-2-12656890.pdf?src-supplier=Mouser; ComponentLink3URL: https://datasheet.ciiva.com/12656/pyu-ac-51-rohs-l-2-12656890.pdf?src-supplier=Mouser; Lead Free: Lead Free; Manufacturer Part Number 1: AC0805FR-070RL; Max Operating Temperature: 155°C; Min Operating Temperature: -55°C; Mount: Surface Mount; Packaging: Tape and Reel; Pricing 1: 1=0,1, 10=0,027, 50=0,0174, 100=0,0146, 500=0,01004, 1000=0,00868 (USD); RoHS 1: Yes; RoHS Compliant: Yes; Series: AC; Stock 1: 20570; Supplier 1: Digi-Key; Supplier Part Number 1: 13-AC0805FR-070RLDKR-ND; Termination: SMD/SMT; Library Name: xEV_SmartOBC.SchLib
R38 R44 R46 = RES_0603_4.7k: RES 4.7K OHM 5% 1/10W 0603; Manufacturer 1: Yageo; Category 1: Chip SMD Resistors; Description 1: Res Thick Film 0603 4.7K Ohm 5% 1/10W ±100ppm/°C Molded SMD Paper T/R; Component Kind: Standard; ComponentLink1Description: http://www.mouser.com/ds/2/447/yageodocoutput-1111992.pdf?src-supplier=Mouser; ComponentLink1URL: http://www.mouser.com/ds/2/447/yageodocoutput-1111992.pdf?src-supplier=Mouser; ComponentLink2Description: https://www.yageo.com/upload/media/product/productsearch/datasheet/rchip/PYu-AC_51_RoHS_L_7.pdf?src-supplier=Digi-Key; ComponentLink2URL: https://www.yageo.com/upload/media/product/productsearch/datasheet/rchip/PYu-AC_51_RoHS_L_7.pdf?src-supplier=Digi-Key; ComponentLink3Description: https://datasheet.octopart.com/AC0603JR-074K7L-Yageo-datasheet-151884373.pdf?src-supplier=Future+Electronics; ComponentLink3URL: https://datasheet.octopart.com/AC0603JR-074K7L-Yageo-datasheet-151884373.pdf?src-supplier=Future+Electronics; Manufacturer Part Number 1: AC0603JR-074K7L; Pricing 1: 1=0,1, 10=0,021, 50=0,0124, 100=0,0103, 500=0,0069, 1000=0,00589 (USD); RoHS 1: Yes; Stock 1: 72300; Supplier 1: Digi-Key; Supplier Part Number 1: YAG3676DKR-ND; Library Name: xEV_SmartOBC.SchLib
R40 R41 = RES_0603_47: 47 Ohms ±1% 0.1W, 1/10W Chip Resistor 0603 (1608 Metric) Automotive AEC-Q200, Moisture Resistant Thick Film; Manufacturer 1: Yageo; Category 1: Chip SMD Resistors; Description 1: SMD Chip Resistor, 47 Ohm, ± 1%, 100 mW, 0603 [1608 Metric], Thick Film, General Purpose; Component Kind: Standard; ComponentLink1Description: https://datasheet.ciiva.com/5558/pyu-ac-51-rohs-l-2-5558053.pdf?src-supplier=Mouser; ComponentLink1URL: https://datasheet.ciiva.com/5558/pyu-ac-51-rohs-l-2-5558053.pdf?src-supplier=Mouser; Manufacturer Part Number 1: AC0603FR-0747RL; Pricing 1: 1=0,1, 10=0,021, 50=0,013, 100=0,0108, 500=0,00734, 1000=0,00633 (USD); RoHS 1: Yes; Stock 1: 52572; Supplier 1: Digikey; Supplier Part Number 1: 311-47LDCT-ND; Library Name: xEV_SmartOBC.SchLib
R42 R43 = RES_1206_2.2R: 2.2 Ohms ±5% 0.75W, 3/4W Chip Resistor 1206 (3216 Metric) Automotive AEC-Q200, Pulse Withstanding Thick Film; Value: 1206 2.2R; Component Kind: Standard; Supplier 1: Digi-Key; Supplier Part Number 1: 541-2.2UADKR-ND; Library Name: xEV_SmartOBC.SchLib
R45 R47 = RES_0603_10k: 10 kOhms ±1% 0.1W, 1/10W Chip Resistor 0603 (1608 Metric) Moisture Resistant Thick Film; Manufacturer 1: Vishay; Category 1: Chip SMD Resistors; Description 1: SMD Chip Resistor, 10 kOhm, ± 1%, 100 mW, 0603 [1608 Metric], Thick Film, General Purpose; Max Power Dissipation: 100mW; Temperature Coefficient: 100ppm/°C; Voltage Rating: 75V; Case Code (Imperial): 0603; Case Code (Metric): 1608; Case/Package: 0603; Component Kind: Standard; ComponentLink1Description: https://datasheet.ciiva.com/11809/0900766b80d98afc-11809037.pdf?src-supplier=Rs; ComponentLink1URL: https://datasheet.ciiva.com/11809/0900766b80d98afc-11809037.pdf?src-supplier=Rs; ComponentLink2Description: https://datasheet.ciiva.com/46385/1697337-46385498.pdf?src-supplier=Element14; ComponentLink2URL: https://datasheet.ciiva.com/46385/1697337-46385498.pdf?src-supplier=Element14; ComponentLink3Description: https://datasheet.ciiva.com/2465/dcrcwe3-2465514.pdf?src-supplier=Digikey; ComponentLink3URL: https://datasheet.ciiva.com/2465/dcrcwe3-2465514.pdf?src-supplier=Digikey; ComponentLink4Description: https://datasheet.ciiva.com/2465/dcrcwe3-2465515.pdf?src-supplier=Digikey; ComponentLink4URL: https://datasheet.ciiva.com/2465/dcrcwe3-2465515.pdf?src-supplier=Digikey; ComponentLink5Description: https://datasheet.ciiva.com/16719/70201119-16719704.pdf?src-supplier=Allied+Electronics; ComponentLink5URL: https://datasheet.ciiva.com/16719/70201119-16719704.pdf?src-supplier=Allied+Electronics; ComponentLink6Description: https://datasheet.ciiva.com/16754/70201119-16754710.pdf?src-supplier=Allied+Electronics; ComponentLink6URL: https://datasheet.ciiva.com/16754/70201119-16754710.pdf?src-supplier=Allied+Electronics; ComponentLink7Description: https://datasheet.ciiva.com/5543/dcrcwe3-109170-5543001.pdf?src-supplier=Mouser; ComponentLink7URL: https://datasheet.ciiva.com/5543/dcrcwe3-109170-5543001.pdf?src-supplier=Mouser; ComponentLink8Description: https://datasheet.ciiva.com/3256/dcrcwe3-3256939.pdf?src-supplier=Avnet; ComponentLink8URL: https://datasheet.ciiva.com/3256/dcrcwe3-3256939.pdf?src-supplier=Avnet; ComponentLink9Description: https://datasheet.ciiva.com/26314/fld-crcw-e3-data-en-26314749.pdf?src-supplier=Distrelec; ComponentLink9URL: https://datasheet.ciiva.com/26314/fld-crcw-e3-data-en-26314749.pdf?src-supplier=Distrelec; ComponentLink10Description: https://datasheet.ciiva.com/2465/dcrcwe3-2465513.pdf?src-supplier=Digikey; ComponentLink10URL: https://datasheet.ciiva.com/2465/dcrcwe3-2465513.pdf?src-supplier=Digikey; ComponentLink11Description: https://datasheet.ciiva.com/3256/dcrcwe3-3256940.pdf?src-supplier=Avnet; ComponentLink11URL: https://datasheet.ciiva.com/3256/dcrcwe3-3256940.pdf?src-supplier=Avnet; ComponentLink12Description: https://datasheet.ciiva.com/16209/dcrcwe3-16209164.pdf?src-supplier=Future+Electronics; ComponentLink12URL: https://datasheet.ciiva.com/16209/dcrcwe3-16209164.pdf?src-supplier=Future+Electronics; ComponentLink13Description: https://datasheet.ciiva.com/26821/getdatasheetpartid-86831-26821109.pdf?src-supplier=Verical; ComponentLink13URL: https://datasheet.ciiva.com/26821/getdatasheetpartid-86831-26821109.pdf?src-supplier=Verical; Construction: Rectangular; Contact Plating: Tin; Depth: 0.85mm; Features: Automotive AEC-Q200; Height: 0.45mm; Lead Free: 5697LeadFree; Length: 1.55mm; Manufacturer Part Number 1: CRCW060310K0FKEA; Max Operating Temperature: 155°C; Military Standard: Not; Min Operating Temperature: -55°C; Number of Pins: 2NUMBER; Number of Terminations: 2; Package Quantity: 10; Packaging: Tape and Reel; Power Rating: 100mW; Pricing 1: 1=0,1, 10=0,026, 50=0,0166, 100=0,0139, 500=0,0095, 1000=0,00821 (USD); Radiation Hardening: No; Reach SVHC Compliant: Unknown; Resistance Tolerance: 1%; Resistor Type: Thick Film; RoHS 1: Yes; RoHS Compliant: Yes; Series: CRCW; Size Code: 0603; Stock 1: 3506368; Supplier 1: Digikey; Supplier Part Number 1: 541-10.0KHCT-ND; Technology: THICK FILM; Termination: SMD/SMT; Tolerance: 1%; Voltage Rating (DC): 75V; Weight: 7.1E-05oz; Width: 0.033inch; Library Name: xEV_SmartOBC.SchLib
R48 R49 = RES_1206_1R: 1 Ohms ±5% 0.75W, 3/4W Chip Resistor 1206 (3216 Metric) Automotive AEC-Q200, Pulse Withstanding Thick Film; Component Kind: Standard; Supplier 1: Digi-Key; Supplier Part Number 1: 541-1.0UACT-ND; Library Name: xEV_SmartOBC.SchLib
R50 = RES_0805_1.1k_0.1%: Res Thin Film 0805 1.1K Ohm 0.1% 1/8W ±25ppm/°C Molded SMD Paper T/R; Value: 0805 1.1k 0.01%; Component Kind: Standard; Library Name: xEV_SmartOBC.SchLib
R51 = RES_0805_1k_0.1%: 1 kOhms ±0.1% 0.125W, 1/8W Chip Resistor 0805 (2012 Metric) Thin Film; Manufacturer 1: Yageo; Manufacturer Part Number 1: RT0805BRE071KL; Category 1: Chip SMD Resistors; Description 1: Res Thin Film 0805 1K Ohm 0.1% 0.125W(1/8W) ±50ppm/C Pad SMD T/R; Value: 0805 1k 0.1%; Component Kind: Standard; ComponentLink1Description: https://datasheet.octopart.com/RT0805BRE071KL-Yageo-datasheet-166250672.pdf?src-supplier=Future+Electronics; ComponentLink1URL: https://datasheet.octopart.com/RT0805BRE071KL-Yageo-datasheet-166250672.pdf?src-supplier=Future+Electronics; ComponentLink2Description: http://www.yageo.com/documents/recent/PYu-RT_1-to-0.05_RoHS_L_(4).pdf?src-supplier=Future+Electronics; ComponentLink2URL: http://www.yageo.com/documents/recent/PYu-RT_1-to-0.05_RoHS_L_(4).pdf?src-supplier=Future+Electronics; ComponentLink3Description: http://www.yageo.com/documents/recent/PYu-RT_1-to-0.01_RoHS_L_9.pdf?src-supplier=Digi-Key; ComponentLink3URL: http://www.yageo.com/documents/recent/PYu-RT_1-to-0.01_RoHS_L_9.pdf?src-supplier=Digi-Key; ComponentLink4Description: https://www.yageo.com/upload/media/product/productsearch/datasheet/rchip/PYu-RT_1-to-0.01_RoHS_L_11.pdf?src-supplier=Digi-Key; ComponentLink4URL: https://www.yageo.com/upload/media/product/productsearch/datasheet/rchip/PYu-RT_1-to-0.01_RoHS_L_11.pdf?src-supplier=Digi-Key; ComponentLink5Description: http://www.yageo.com/documents/recent/PYu-RT_1-to-0.05_RoHS_L_6.pdf?src-supplier=Avnet; ComponentLink5URL: http://www.yageo.com/documents/recent/PYu-RT_1-to-0.05_RoHS_L_6.pdf?src-supplier=Avnet; Pricing 1: 1=0,41, 10=0,298, 50=0,1772, 100=0,125, 500=0,09544, 1000=0,07272 (USD); RoHS 1: Yes; Stock 1: 19704; Supplier 1: Digi-Key; Supplier Part Number 1: YAG2328DKR-ND; Library Name: xEV_SmartOBC.SchLib
T5 = B82787C0513H002: CMC 51UH 200MA 2LN SMD AEC-Q200; Manufacturer: EPCOS - TDK; Component Kind: Standard; Package/Case: Horizontal, 4 PC Pad; Supplier 1: Digi-Key; Supplier Part Number: 495-4597-1-ND; Supplier Part Number 1: 495-4597-1-ND; Value: B82787C0513H002; Library Name: xEV_SmartOBC.SchLib
TVS11 TVS12 = TVS Diode 20V: 32.4V Clamp 6.2A Ipp Tvs Diode Surface Mount SOD-123F; Manufacturer 1: Littelfuse; Description 1: SMF Series 24.5 V 200 W Uni-Directional Surface Mount TVS Diode - SOD-123; Category 1: TVS Diodes; Component Kind: Standard; ComponentLink1Description: https://datasheet.ciiva.com/6577/littelfuse-tvs-diode-smf-datasheet-pdf-6577846.pdf?src-supplier=Digi-Key; ComponentLink1URL: https://datasheet.ciiva.com/6577/littelfuse-tvs-diode-smf-datasheet-pdf-6577846.pdf?src-supplier=Digi-Key; ComponentLink2Description: https://datasheet.ciiva.com/6577/littelfuse-tvs-diode-smf-datasheet-pdf-6577847.pdf?src-supplier=Digi-Key; ComponentLink2URL: https://datasheet.ciiva.com/6577/littelfuse-tvs-diode-smf-datasheet-pdf-6577847.pdf?src-supplier=Digi-Key; ComponentLink3Description: https://datasheet.ciiva.com/6577/littelfuse-tvs-diode-smf-datasheet-pdf-6577849.pdf?src-supplier=Digi-Key; ComponentLink3URL: https://datasheet.ciiva.com/6577/littelfuse-tvs-diode-smf-datasheet-pdf-6577849.pdf?src-supplier=Digi-Key; Manufacturer Part Number 1: SMF20A; Pricing 1: 1=0,48, 10=0,36, 100=0,224, 500=0,15328, 1000=0,11791 (USD); RoHS 1: Yes; Stock 1: 22165; Supplier 1: Digi-Key; Supplier Part Number 1: F5821CT-ND; Library Name: xEV_SmartOBC.SchLib
TVS13 TVS14 = TVS Diode 7V: 12V Clamp 16.7A Ipp Tvs Diode Surface Mount SOD-123F; Manufacturer 1: Littelfuse; Description 1: TVS Diodes - Transient Voltage Suppressors 7V 200W 16.7A 5% Unidirectional; Category 1: TVS Diodes; Component Kind: Standard; ComponentLink1Description: https://datasheet.ciiva.com/6574/littelfuse-tvs-diode-smf-datasheet-pdf-6574037.pdf?src-supplier=Digi-Key; ComponentLink1URL: https://datasheet.ciiva.com/6574/littelfuse-tvs-diode-smf-datasheet-pdf-6574037.pdf?src-supplier=Digi-Key; Manufacturer Part Number 1: SMF7.0A; Pricing 1: 1=0,37, 10=0,297, 100=0,2024, 500=0,15178, 1000=0,11383 (USD); RoHS 1: Yes; Stock 1: 13873; Supplier 1: Digi-Key; Supplier Part Number 1: F5751DKR-ND; Library Name: xEV_SmartOBC.SchLib
U10 = PESE2-S24-S24-M: Isolated Module DC DC Converter 1 Output 24V 83mA 21.6V - 26.4V Input; Manufacturer 1: CUI; Manufacturer Part Number 1: PESE2-S24-S24-M-TR; Category 1: Board Mount Modules; Description 1: Dc-dc 3KV Isolated, 2 W, 21.6~26; Component Kind: Standard; Pricing 1: 1=6,83, 5=6,728, 10=6,631, 25=6,4364, 50=6,2412, 100=6,0462, 250=5,8512 (USD); RoHS 1: No; Stock 1: 500; Supplier 1: Digi-Key; Supplier Part Number 1: 102-PESE2-S24-S24-M-TRCT-ND; Library Name: xEV_SmartOBC.SchLib
U12 U13 = NCV57081CDR2G: 6.5A Gate Driver Capacitive Coupling 3750Vrms 1 Channel 8-SOIC; Manufacturer 1: ON Semiconductor; Description 1: IGBT and MOSFET Gate Driver 6.5A 1-OUT Inverting/Non-Inverting 8-Pin SOIC N T/R; Component Kind: Standard; Manufacturer Part Number 1: NCV57081CDR2G; Pricing 1: 1=5,35, 10=4,808, 25=4,5448, 100=3,737, 250=3,3532, 500=3,232, 1000=2,6866 (USD); RoHS 1: No; Stock 1: 2412; Supplier 1: Digi-Key; Supplier Part Number 1: 488-NCV57081CDR2GCT-ND; Library Name: xEV_SmartOBC.SchLib
U14 U15 = IES0105S05-TR: Isolated Module DC DC Converter 1 Output 5V 200mA 4.5V - 5.5V Input; Component Kind: Standard; ComponentLink1Description: https://www.xppower.com/portals/0/pdfs/SF_IES01.pdf?src-supplier=Digi-Key; ComponentLink1URL: https://www.xppower.com/portals/0/pdfs/SF_IES01.pdf?src-supplier=Digi-Key; ComponentLink2Description: https://datasheet.octopart.com/IES0105S05-XP-Power-datasheet-165595716.pdf?src-supplier=TME; ComponentLink2URL: https://datasheet.octopart.com/IES0105S05-XP-Power-datasheet-165595716.pdf?src-supplier=TME; ComponentLink3Description: https://datasheet.octopart.com/IES0105S05-H-XP-Power-datasheet-165595716.pdf?src-supplier=TME; ComponentLink3URL: https://datasheet.octopart.com/IES0105S05-H-XP-Power-datasheet-165595716.pdf?src-supplier=TME; Supplier 1: Digikey; Supplier Part Number 1: 1470-IES0105S05-CT-ND; Library Name: xEV_SmartOBC.SchLib
U16 U17 = AMC1300BQDWVRQ1: Isolation Amplifier 1 Circuit 8-SOIC; Manufacturer 1: Texas Instruments; Category 1: Integrated Circuits (ICs); Description 1: Automotive ±250-mV input, reinforced isolated amplifier with high CMTI for current sensing 8-SOIC -40 to 125; Component Kind: Standard; ComponentLink1Description: https://datasheet.ciiva.com/32413/acplc790000e-eng-tds-32413595.pdf?src-supplier=Distrelec; ComponentLink1URL: https://datasheet.ciiva.com/32413/acplc790000e-eng-tds-32413595.pdf?src-supplier=Distrelec; ComponentLink2Description: https://datasheet.ciiva.com/1134/1676918-1134018.pdf?src-supplier=Element14; ComponentLink2URL: https://datasheet.ciiva.com/1134/1676918-1134018.pdf?src-supplier=Element14; Manufacturer Part Number 1: AMC1300BQDWVRQ1; Pricing 1: 1=6,24, 10=5,637, 25=5,3752, 100=4,6671, 250=4,45732, 500=4,06404 (USD); RoHS 1: Yes; Stock 1: 1816; Supplier 1: Digi-Key; Supplier Part Number 1: 296-AMC1300BQDWVRQ1CT-ND; Library Name: xEV_SmartOBC.SchLib
